# Altium schematic sheet PiModulos V2 - Mayonaise.SchDoc("PiModulos V2 - Mayonaise")
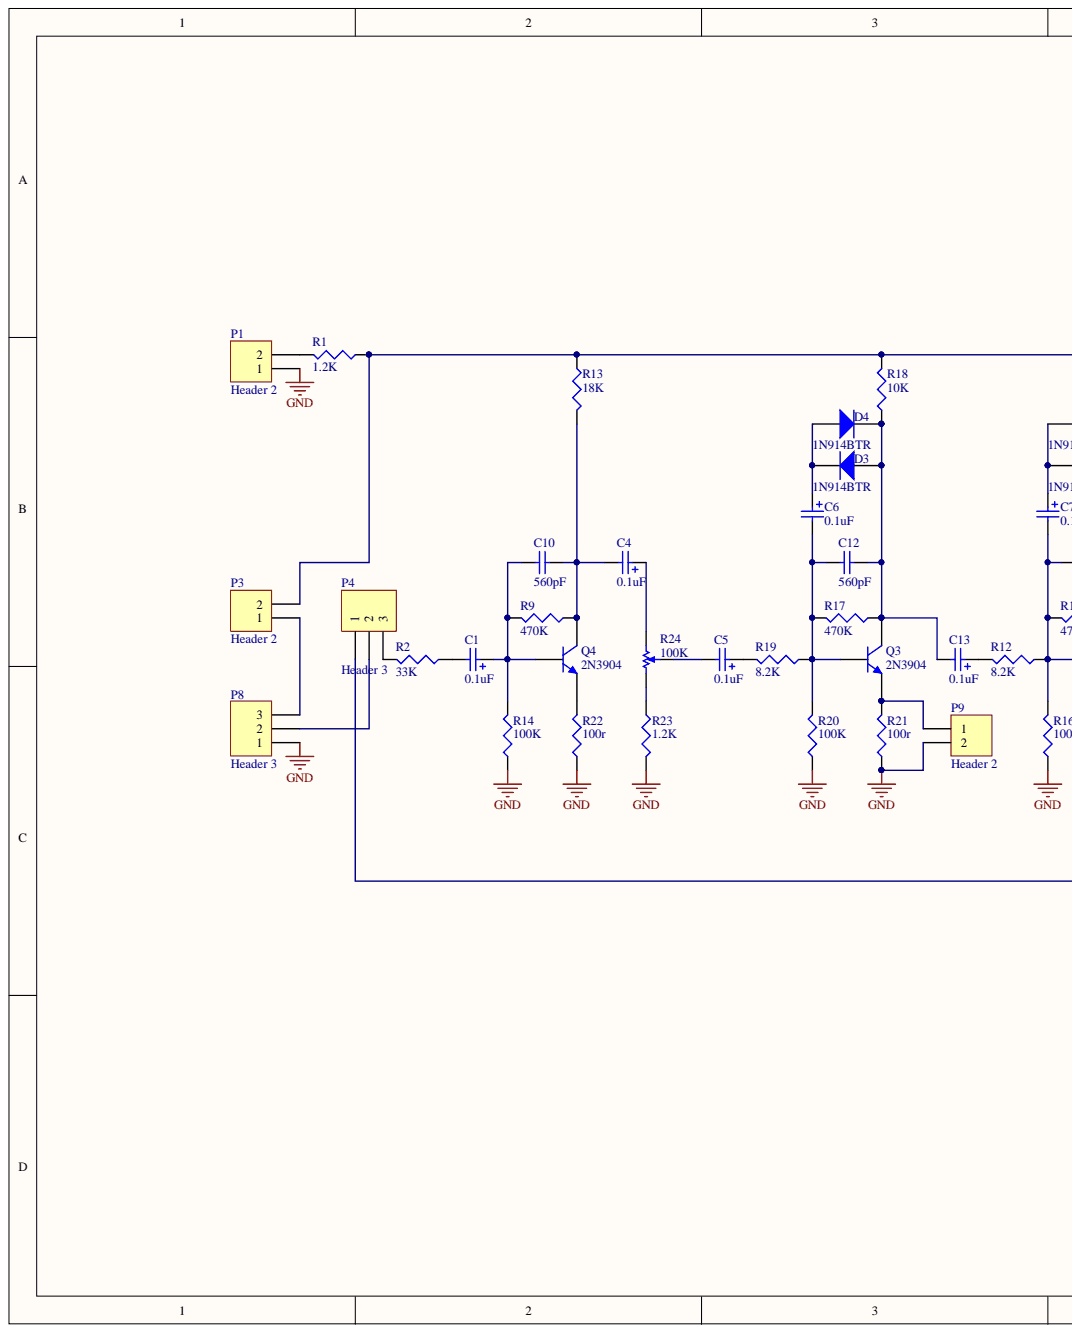
[diagram p0: PiModulos V2 - Mayonaise.SchDoc, left]
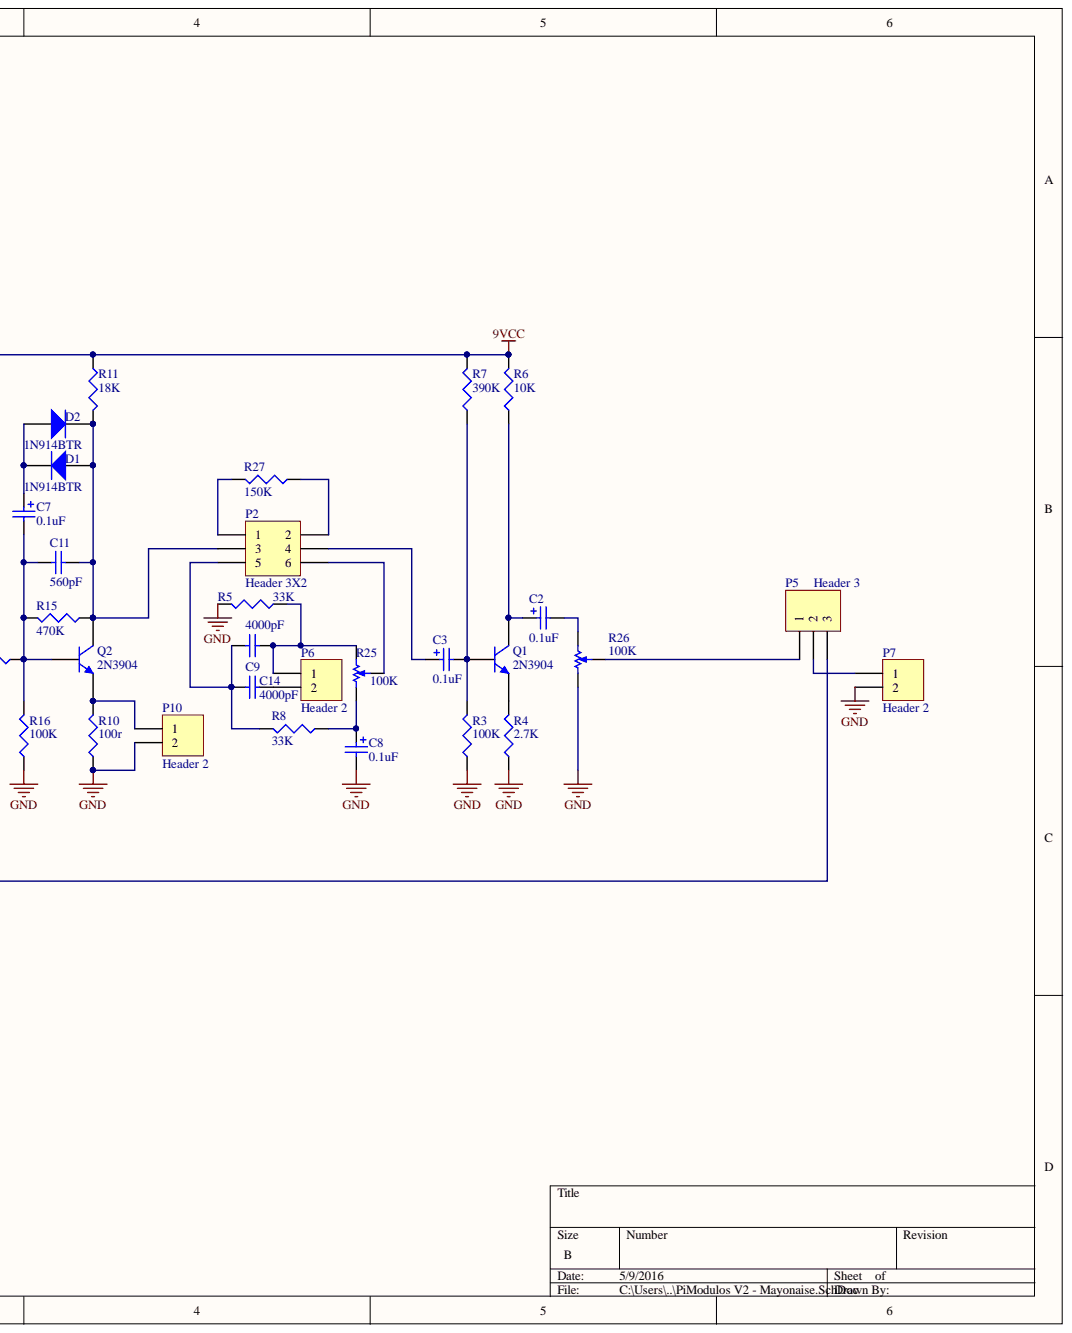
[diagram p1: PiModulos V2 - Mayonaise.SchDoc, right]

## Parts
C1  0.1uF  [5X12.5mmCAP]
C2  0.1uF  [5X12.5mmCAP]
C3  0.1uF  [5X12.5mmCAP]
C4  0.1uF  [5X12.5mmCAP]
C5  0.1uF  [5X12.5mmCAP]
C6  0.1uF  [5X12.5mmCAP]
C7  0.1uF  [5X12.5mmCAP]
C8  0.1uF  [5X12.5mmCAP]
C9  4000pF  [30LVD40-R]
C10  560pF  [C0805]
C11  560pF  [C0805]
C12  560pF  [C0805]
C13  0.1uF  [5X12.5mmCAP]
C14  4000pF  [30LVD40-R]
D1  1N914BTR  [DO-35]
D2  1N914BTR  [DO-35]
D3  1N914BTR  [DO-35]
D4  1N914BTR  [DO-35]
P1  Header 2  [HDR1X2]
P2  Header 3X2  [HDR2X3]
P3  Header 2  [HDR1X2]
P4  Header 3  [HDR1X3]
P5  Header 3  [HDR1X3]
P6  Header 2  [HDR1X2]
P7  Header 2  [HDR1X2]
P8  Header 3  [HDR1X3]
P9  Header 2  [HDR1X2]
P10  Header 2  [HDR1X2]
Q1  2N3904  [TO-92A]
Q2  2N3904  [TO-92A]
Q3  2N3904  [TO-92A]
Q4  2N3904  [TO-92A]
R1  1.2K  [R0805]
R2  33K  [R0805]
R3  100K  [R0805]
R4  2.7K  [R0805]
R5  33K  [R0805]
R6  10K  [R0805]
R7  390K  [R0805]
R8  33K  [R0805]
R9  470K  [R0805]
R10  100r  [R0805]
R11  18K  [R0805]
R12  8.2K  [R0805]
R13  18K  [R0805]
R14  100K  [R0805]
R15  470K  [R0805]
R16  100K  [R0805]
R17  470K  [R0805]
R18  10K  [R0805]
R19  8.2K  [R0805]
R20  100K  [R0805]
R21  100r  [R0805]
R22  100r  [R0805]
R23  1.2K  [R0805]
R24  100K  [P0915N-FC15BR100K]
R25  100K  [P0915N-FC15BR100K]
R26  100K  [P0915N-FC15BR100K]
R27  150K  [R0805]

## Nets
9VCC: P3.2 R1.1 R6.2 R7.2 R11.1 R13.1 R18.2
GND: C8.2 P1.1 P7.2 P8.1 P9.2 P10.2 R3.1 R4.1 R5.2 R10.1 R14.1 R16.1 R20.1 R21.1 R22.1 R23.2 R26.CCW
NetC1_1: C1.1 C10.2 Q4.2 R9.2 R14.2
NetC1_2: C1.2 R2.1
NetC2_1: C2.1 Q1.3 R6.1
NetC2_2: C2.2 R26.CW
NetC3_1: C3.1 P2.4
NetC3_2: C3.2 Q1.2 R3.2 R7.1
NetC4_1: C4.1 R24.CW
NetC4_2: C4.2 C10.1 Q4.3 R9.1 R13.2
NetC5_1: C5.1 R19.2
NetC5_2: C5.2 R24.W
NetC6_1: C6.1 D3.2 D4.1
NetC6_2: C6.2 C12.2 Q3.2 R17.2 R19.1 R20.2
NetC7_1: C7.1 D1.2 D2.1
NetC7_2: C7.2 C11.2 Q2.2 R12.1 R15.2 R16.2
NetC8_1: C8.1 R8.1 R25.CCW
NetC9_1: C9.1 P6.1 R5.1 R25.CW
NetC9_2: C9.2 C14.2 P2.5 R8.2
NetC11_1: C11.1 D1.1 D2.2 P2.3 Q2.3 R11.2 R15.1
NetC12_1: C12.1 C13.2 D3.1 D4.2 Q3.3 R17.1 R18.1
NetC13_1: C13.1 R12.2
NetC14_1: C14.1 P6.2
NetP1_2: P1.2 R1.2
NetP2_1: P2.1 R27.2
NetP2_2: P2.2 R27.1
NetP2_6: P2.6 R25.W
NetP3_1: P3.1 P8.3
NetP4_1: P4.1 P5.3
NetP4_2: P4.2 P8.2
NetP4_3: P4.3 R2.2
NetP5_1: P5.1 R26.W
NetP5_2: P5.2 P7.1
NetP9_1: P9.1 Q3.1 R21.2
NetP10_1: P10.1 Q2.1 R10.2
NetQ1_1: Q1.1 R4.2
NetQ4_1: Q4.1 R22.2
NetR23_1: R23.1 R24.CCW

## Library data of the parts
C1-C8 C13 = UFG2A0R1MDM1TD: CAP ALUM 0.1UF 20% 100V RADIAL; MFR: Nichicon; Designer: Adam Vadala-Roth; Lead-Free ?: Yes; Pin Count #: 2; Type: Aluminum Capacitor Throughhole; Component Kind: Standard; Cost: 0.08413 @ @ 1000; Datasheet: http://nichicon-us.com/english/products/pdfs/e-ufg.pdf; Date Created: 9 May 2016; Height: 12.5mm; Last Modified: 9 May 2016; Library Name: AudioPartLib.SchLib; MFR #: UFG2A0R1MDM1TD; Mounting: SMT/SMD; Status: Active; Tolerance: 20%; Value: 0.1uF; Voltage: 100V
C9 C14 = 30LVD40-R: Ceramic Disc Capacitors .25LS 4000PF 300V 20%; MFR: Vishay Cera-Mite; Designer: Adam Vadala-Roth; Lead-Free ?: Yes; Pin Count #: 2; Type: Ceramic Capacitor THroughhole; Component Kind: Standard; Cost: 0.855 @ 1000; Datasheet: http://www.mouser.com/ds/2/427/30lv-239712.pdf; Date Created: 9 May 2016; Height: 13.5mm; Last Modified: 9 May 2016; Library Name: AudioPartLib.SchLib; MFR #: 30LVD40-R; Mounting: SMT/SMD; Status: Active; Tolerance: 20%; Value: 4000pF; Voltage: 300V
C10-C12 = CL21C561JBANNNC: 560pF ±5% 50V C0G, NP0 Ceramic Capacitor -55°C ~ 125°C Surface Mount, MLCC 0805 (2012 Metric) 0.079" L x 0.049" W (2.00mm x 1.25mm; MFR: Samsung-Electro-Mechanics-America,-Inc.; Designer: Adam Vadala-Roth; Lead-Free ?: Yes; Pin Count #: 2; Type: Ceramic Capacitor 0805; Component Kind: Standard; Cost: 0.02574; Datasheet: http://www.samsungsem.com/kr/support/product-search/mlcc/__icsFiles/afieldfile/2015/06/03/CL21C561JBANNNC.pdf; Date Created: 9 May 2016; Height: 0.75mm; Last Modified: 9 May 2016; Library Name: AudioPartLib.SchLib; MFR #: CL21C561JBANNNC; Mounting: SMT/SMD; Status: Active; Tolerance: 5%; Value: 560pF; Voltage: 50V
D1-D4 = 1N914BTR: DIODE GEN PURP 100V 200MA DO35; MFR: Fairchild-Semiconductor; Designer: Adam Vadala-Roth; Lead-Free ?: Yes; Pin Count #: 2; Type: Throughhole Diode; Component Kind: Standard; Cost: 0.009 @ 1000; Datasheet: https://www.fairchildsemi.com/datasheets/1N/1N914.pdf; Date Created: 8 May 2016; Height: 4mm; Last Modified: 8 May 2016; Library Name: AudioPartLib.SchLib; MFR #: 1N914BTR; Mounting: SMT/SMD; Status: Active; Tolerance: N/A; Value: 1N914BTR; Voltage: 100V
P1 P3 P6 P7 P9 P10 = Header 2: Header, 2-Pin; Component Kind: Standard; LatestRevisionDate: 17-Jul-2002; LatestRevisionNote: Re-released for DXP Platform.; Library Name: Miscellaneous Connectors.IntLib; Signal Integrity: Connector; Publisher: Altium Limited
P2 = Header 3X2: Header, 3-Pin, Dual row; Component Kind: Standard; LatestRevisionDate: 17-Jul-2002; LatestRevisionNote: Re-released for DXP Platform.; Library Name: Miscellaneous Connectors.IntLib; Signal Integrity: Connector; Publisher: Altium Limited
P4 P5 P8 = Header 3: Header, 3-Pin; Component Kind: Standard; LatestRevisionDate: 17-Jul-2002; LatestRevisionNote: Re-released for DXP Platform.; Library Name: Miscellaneous Connectors.IntLib; Signal Integrity: Connector; Publisher: Altium Limited
Q1-Q4 = 2N3904TF: TRANS NPN 40V 0.2A TO-92; MFR: Fairchild-Semiconductor; Designer: Adam Vadala-Roth; Lead-Free ?: Yes; Pin Count #: 2; Type: NPN Transistor; Component Kind: Standard; Cost: 0.03468 @ 2000; Datasheet: https://www.fairchildsemi.com/datasheets/MM/MMBT3904.pdf; Date Created: 9 May 2016; Height: 5mm; Last Modified: 9 May 2016; Library Name: AudioPartLib.SchLib; MFR #: 2N3904TF; Mounting: SMT/SMD; Status: Active; Tolerance: N/A; Value: 2N3904; Voltage: 40V
R1 R23 = ERJ-6ENF1201V: RES SMD 1.2K OHM 1% 1/8W 0805; MFR: Panasonic-Electronic-Components; Designer: Adam Vadala-Roth; Lead-Free ?: Yes; Pin Count #: 2; Component Kind: Standard; Cost: 0.00729 @ 1000; Datasheet: http://industrial.panasonic.com/www-cgi/jvcr13pz.cgi?E+PZ+3+AOA0002+ERJ6ENF1201V+7+WW; Date Created: 8 May 2016; Height: 0.7mm; Last Modified: 8 May 2016; Library Name: AudioPartLib.SchLib; MFR #: ERJ-6ENF1201V; Mounting: SMT/SMD; Status: Active; Tolerance: 1%; Type: Chip Resistor 0805; Value: 1.2K; Voltage: 1/8W
R2 R5 R8 = ERJ-6ENF3302V: RES SMD 33K OHM 1% 1/8W 0805; MFR: Panasonic-Electronic-Components; Designer: Adam Vadala-Roth; Lead-Free ?: Yes; Pin Count #: 2; Component Kind: Standard; Cost: 0.00729; Datasheet: http://industrial.panasonic.com/www-cgi/jvcr13pz.cgi?E+PZ+3+AOA0002+ERJ6ENF3302V+7+WW; Date Created: 9 May 2016; Height: 0.7mm; Last Modified: 9 May 2016; Library Name: AudioPartLib.SchLib; MFR #: ERJ-6ENF3302V; Mounting: SMT/SMD; Status: Active; Tolerance: 1%; Type: Chip Resistor 0805; Value: 33K; Voltage: 1/8W
R3 R14 R16 R20 = ERJ-6ENF1003V: RES SMD 100K OHM 1% 1/8W 0805; MFR: Panasonic-Electronic-Components; Designer: Adam Vadala-Roth; Lead-Free ?: Yes; Pin Count #: 2; Component Kind: Standard; Cost: 0.00729 @ 1000; Datasheet: http://industrial.panasonic.com/www-cgi/jvcr13pz.cgi?E+PZ+3+AOA0002+ERJ6ENF1003V+7+WW; Date Created: 9 May 2016; Height: 0.7mm; Last Modified: 9 May 2016; Library Name: AudioPartLib.SchLib; MFR #: ERJ-6ENF1003V; Mounting: SMT/SMD; Status: Active; Tolerance: 1%; Type: Chip Resistor; Value: 100K; Voltage: 1/8W
R4 = RC2012F272CS: RES SMD 2.7K OHM 1% 1/8W 0805; MFR: Samsung-Electro-Mechanics-America,-Inc.; Designer: Adam Vadala-Roth; Lead-Free ?: Yes; Pin Count #: 2; Component Kind: Standard; Cost: 0.004080 @ 1000; Datasheet: https://media.digikey.com/pdf/Data%20Sheets/Samsung%20PDFs/RC_Series_ds.pdf; Date Created: 8 May 2016; Height: 0.6mm; Last Modified: 8 May 2016; Library Name: AudioPartLib.SchLib; MFR #: RC2012F272CS; Mounting: SMT/SMD; Status: Active; Tolerance: 1%; Type: Chip Resistor 0805; Value: 2.7K; Voltage: 1/8W
R6 R18 = ERJ-6ENF1002V: RES SMD 10K OHM 1% 1/8W 0805; MFR: Panasonic-Electronic-Components; Designer: Adam Vadala-Roth; Lead-Free ?: Yes; Pin Count #: 2; Component Kind: Standard; Cost: 0.00729 @ 1000; Datasheet: http://industrial.panasonic.com/www-cgi/jvcr13pz.cgi?E+PZ+3+AOA0002+ERJ6ENF1002V+7+WW; Date Created: 8 May 2016; Height: 0.7mm; Last Modified: 8 May 2016; Library Name: AudioPartLib.SchLib; MFR #: ERJ-6ENF1002V; Mounting: SMT/SMD; Status: Active; Tolerance: 1%; Type: Chip Resistor 0805; Value: 10K; Voltage: 1/8W
R7 = ERJ-6ENF3903V: RES SMD 390K OHM 1% 1/8W 0805; MFR: Panasonic-Electronic-Components; Designer: Adam Vadala-Roth; Lead-Free ?: Yes; Pin Count #: 2; Component Kind: Standard; Cost: 0.00729 @ 1000; Datasheet: http://industrial.panasonic.com/www-cgi/jvcr13pz.cgi?E+PZ+3+AOA0002+ERJ6ENF3903V+7+WW; Date Created: 8 May 2016; Height: 0.7mm; Last Modified: 8 May 2016; Library Name: AudioPartLib.SchLib; MFR #: ERJ-6ENF3903V; Mounting: SMT/SMD; Status: Active; Tolerance: 1%; Type: Chip Resistor 0805; Value: 390K; Voltage: 1/8W
R9 R15 R17 = ERJ-6ENF4703V: RES SMD 470K OHM 1% 1/8W 0805; MFR: Panasonic-Electronic-Components; Designer: Adam Vadala-Roth; Lead-Free ?: Yes; Pin Count #: 2; Component Kind: Standard; Cost: 0.00729	 @ 1000; Datasheet: http://industrial.panasonic.com/www-cgi/jvcr13pz.cgi?E+PZ+3+AOA0002+ERJ6ENF4703V+7+WW; Date Created: 9 May 2016; Height: 0.7mm; Last Modified: 9 May 2016; Library Name: AudioPartLib.SchLib; MFR #: ERJ-6ENF4703V; Mounting: SMT/SMD; Status: Active; Tolerance: 1%; Type: Chip Resistor 0805; Value: 470K; Voltage: 1/8W
R10 R21 R22 = CRCW0805100RFKEA: RES SMD 100 OHM 1% 1/8W 0805; MFR: Vishay-Dale; Designer: Adam Vadala-Roth; Lead-Free ?: Yes; Pin Count #: 2; Component Kind: Standard; Cost: 0.00729 @ 1000; Datasheet: https://www.vishay.com/docs/20035/dcrcwe3.pdf; Date Created: 9 May 2016; Height: 0.5mm; Last Modified: 9 May 2016; Library Name: AudioPartLib.SchLib; MFR #: CRCW0805100RFKEA; Mounting: SMT/SMD; Status: Active; Tolerance: 1%; Type: Chip Resistor 0805; Value: 100r; Voltage: 1/8W
R11 R13 = ERJ-6ENF1802V: RES SMD 18K OHM 1% 1/8W 0805; MFR: Panasonic-Electronic-Components; Designer: Adam Vadala-Roth; Lead-Free ?: Yes; Pin Count #: 2; Component Kind: Standard; Cost: 0.00729 @ 1000; Datasheet: http://industrial.panasonic.com/www-cgi/jvcr13pz.cgi?E+PZ+3+AOA0002+ERJ6ENF1802V+7+WW; Date Created: 8 May 2016; Height: 0.7mm; Last Modified: 8 May 2016; Library Name: AudioPartLib.SchLib; MFR #: ERJ-6ENF1802V; Mounting: SMT/SMD; Status: Active; Tolerance: 1%; Type: Chip Resistor 0805; Value: 18K; Voltage: 1/8W
R12 R19 = RC2012F822CS: RES SMD 8.2K OHM 1% 1/8W 0805; MFR: Samsung-Electro-Mechanics-America,-Inc.; Designer: Adam Vadala-Roth; Lead-Free ?: Yes; Pin Count #: 2; Component Kind: Standard; Cost: 0.00408 @ 1000; Datasheet: https://media.digikey.com/pdf/Data%20Sheets/Samsung%20PDFs/RC_Series_ds.pdf; Date Created: 9 May 2016; Height: 0.6mm; Last Modified: 9 May 2016; Library Name: AudioPartLib.SchLib; MFR #: RC2012F822CS; Mounting: SMT/SMD; Status: Active; Tolerance: 1%; Type: Chip Resistor; Value: 8.2K; Voltage: 1/8W
R24-R26 = P0915N-FC15BR100K: POT 100K OHM 1/20W PLASTIC LIN; MFR: TT-Electronics-BI; Designer: Adam Vadala-Roth; Lead-Free ?: Yes; Pin Count #: 2; Tolerance: 1%; Component Kind: Standard; Cost: 0.50540 @ 1000; Datasheet: http://www.bitechnologies.com/pdfs/p09x.pdf; Date Created: 9 May 2016; Height: 22.6mm; Last Modified: 9 May 2016; Library Name: AudioPartLib.SchLib; MFR #: P0915N-FC15BR100K; Mounting: SMT/SMD; Status: Active; Type: Potentiometer PCB Mounted Vertical; Value: 100K; Voltage: 1/20W
R27 = RC2012F154CS: RES SMD 150K OHM 1% 1/8W 0805; MFR: Samsung-Electro-Mechanics-America,-Inc.; Designer: Adam Vadala-Roth; Lead-Free ?: Yes; Pin Count #: 2; Component Kind: Standard; Cost: 0.00408 @ 10000; Datasheet: https://media.digikey.com/pdf/Data%20Sheets/Samsung%20PDFs/RC_Series_ds.pdf; Date Created: 8 May 2016; Height: 0.6mm; Last Modified: 8 May 2016; Library Name: AudioPartLib.SchLib; MFR #: RC2012F154CS; Mounting: SMT/SMD; Status: Active; Tolerance: 1%; Type: Chip Resistor; Value: 150K; Voltage: 1/8W
